# Altium schematic sheet Tesla_Giraffe.SchDoc(Tesla_Giraffe)
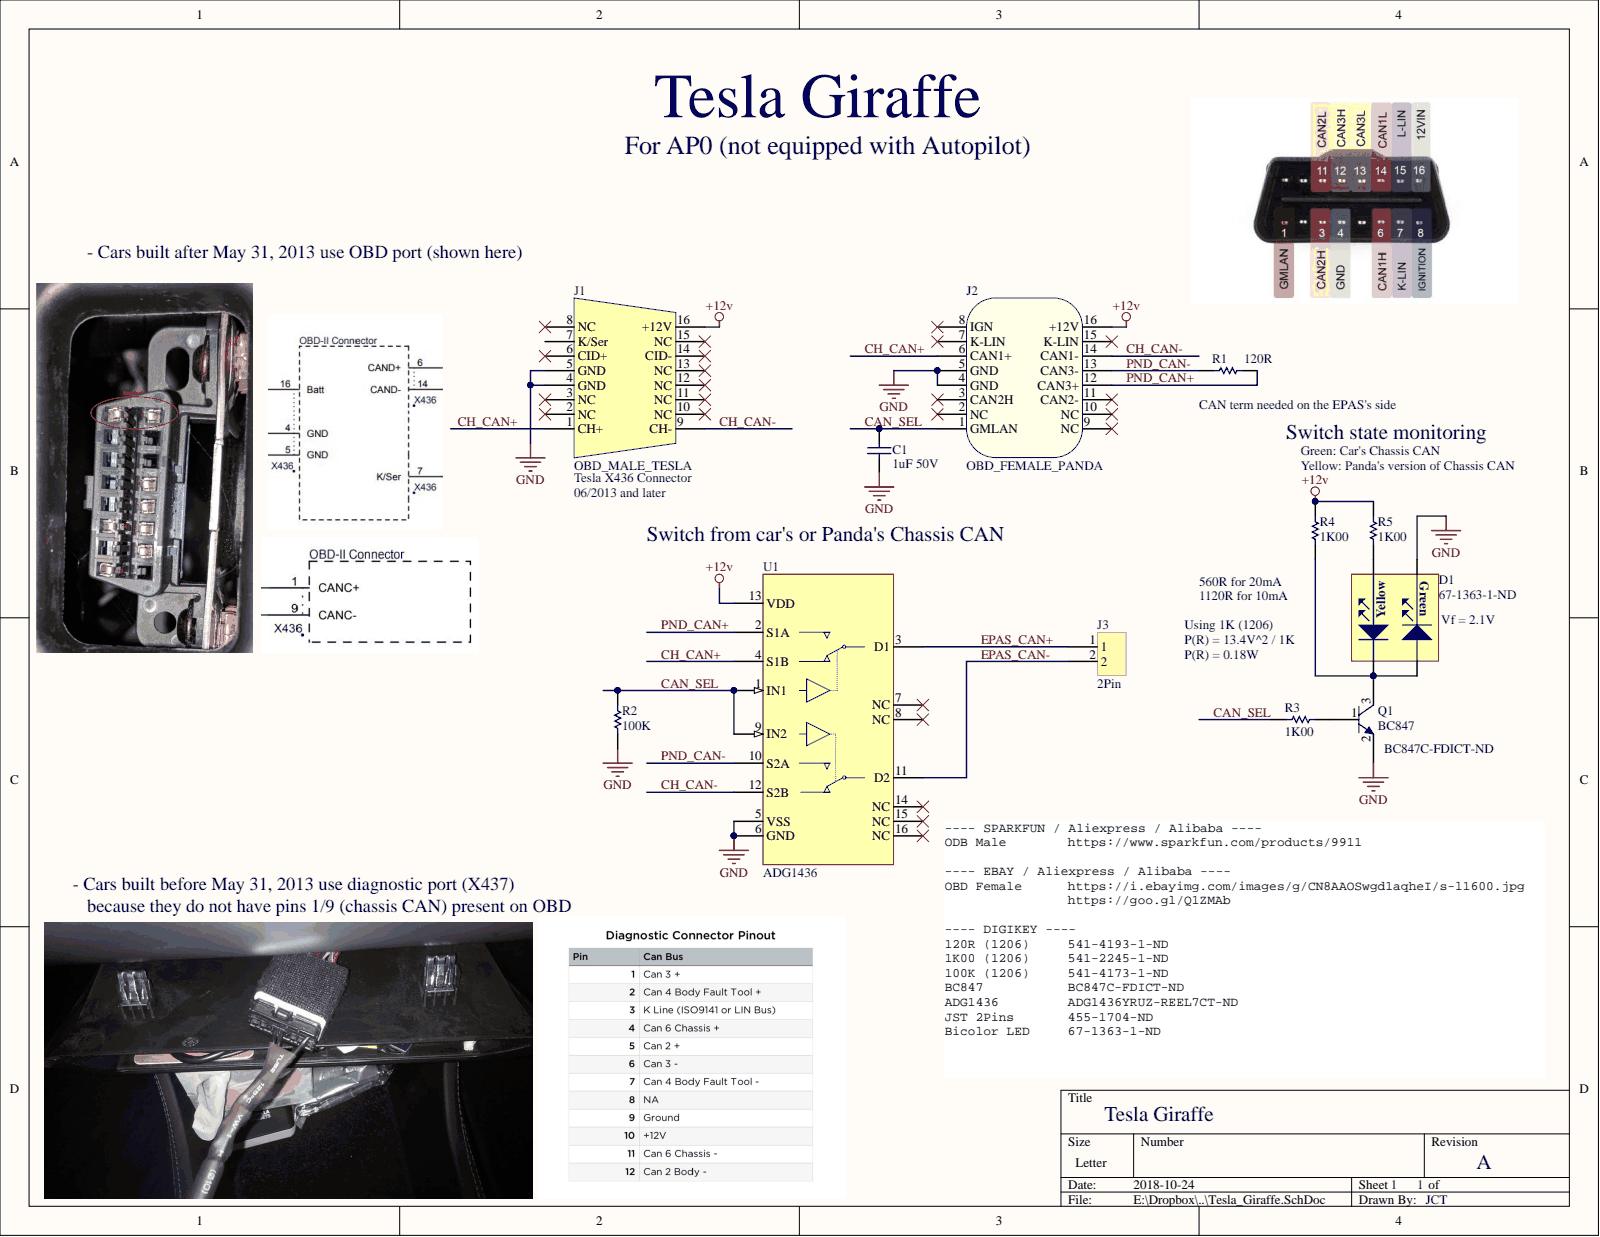
[diagram p0: Tesla_Giraffe.SchDoc]

## Parts
C1  1uF 50V  [R_1206]
D1  67-1363-1-ND  [LED_BICOLOR_1210]
J1  OBD_MALE_TESLA  [OBD_MALE]
J2  OBD_FEMALE_PANDA  [OBD_FEMALE]
J3  2Pin  [JST_PH_2PINS]
Q1  BC847  [SOT23-3N]
R1  120R  [R_1206]
R2  100K  [R_1206]
R3  1K00  [R_1206]
R4  1K00  [R_1206]
R5  1K00  [R_1206]
U1  ADG1436  [TSSOP16]

## Nets
+12v: J1.16(+12V) J2.16(+12V) R4.2 R5.2 U1.13(VDD)
GND: C1.1 D1.2C J1.4(GND) J1.5(GND) J2.4(GND) J2.5(GND) Q1.2 R2.1 U1.5(VSS) U1.6(GND)
CAN_SEL: C1.2 J2.1(GMLAN) R2.2 R3.2 U1.1(IN1) U1.9(IN2)
CH_CAN+: J1.1(CH+) J2.6(CAN1+) U1.4(S1B)
CH_CAN-: J1.9(CH-) J2.14(CAN1-) U1.12(S2B)
EPAS_CAN+: J3.1 U1.3(D1)
EPAS_CAN-: J3.2 U1.11(D2)
PND_CAN+: J2.12(CAN3+) R1.2 U1.2(S1A)
PND_CAN-: J2.13(CAN3-) R1.1 U1.10(S2A)
NetD1_1A: D1.1A R5.1
NetD1_1C: D1.1C D1.2A Q1.3 R4.1
NetQ1_1: Q1.1 R3.1

Not connected: J1 2(NC) 3(NC) 6(CID+) 7(K/Ser) 8(NC) 10(NC) 11(NC) 12(NC) 13(NC) 14(CID-) 15(NC); J2 2(NC) 3(CAN2H) 7(K-LIN) 8(IGN) 9(NC) 10(NC) 11(CAN2-) 15(K-LIN); U1 7(NC) 8(NC) 14(NC) 15(NC) 16(NC)

## Library data of the parts
C1 = CAPACITOR NP: Capacitor (Semiconductor SIM Model); Library Name: parts.SchLib; Component Kind: Standard
D1 = SML-LX1210YGC-TR: SMD Dual (Green-Yellow) Led in a 1210 package; Part_type: Diode/LED/Dual; Manufacturer: Lumex; Catalog_part_number: 67-1363-2-ND; Database Table Name: discretes; Catalog_name: Digikey; Value: SML-LX1210YGC-TR; Part_number: SML-LX1210YGC-TR; Library Name: Qtec_SVN.SVNDBLib; ComponentLink1Description: Datasheet; Component Kind: Standard
J1 = OBD_MALE_TESLA: OBD Male connector; Library Name: parts.SchLib; Component Kind: Standard
J2 = OBD_FEMALE_PANDA: OBD Female connector; Library Name: parts.SchLib; Component Kind: Standard
Q1 = NPN: NPN Bipolar Transistor; Library Name: parts.SchLib; Component Kind: Standard
R1-R5 = RESISTOR: Semiconductor Resistor; Library Name: parts.SchLib; Component Kind: Standard
U1 = ADG1436: 1.5 Ω On Resistance,  ±15 V/12 V/±5 V, iCMOS, Dual SPDT Switch; Library Name: parts.SchLib; Component Kind: Standard
J3 = 2Pin: Library Name: parts.SchLib; Component Kind: Standard
